# Altium schematic sheet CH1_4_INPUT_CONDITIONING.SchDoc(CH1_4_INPUT_CONDITIONING)
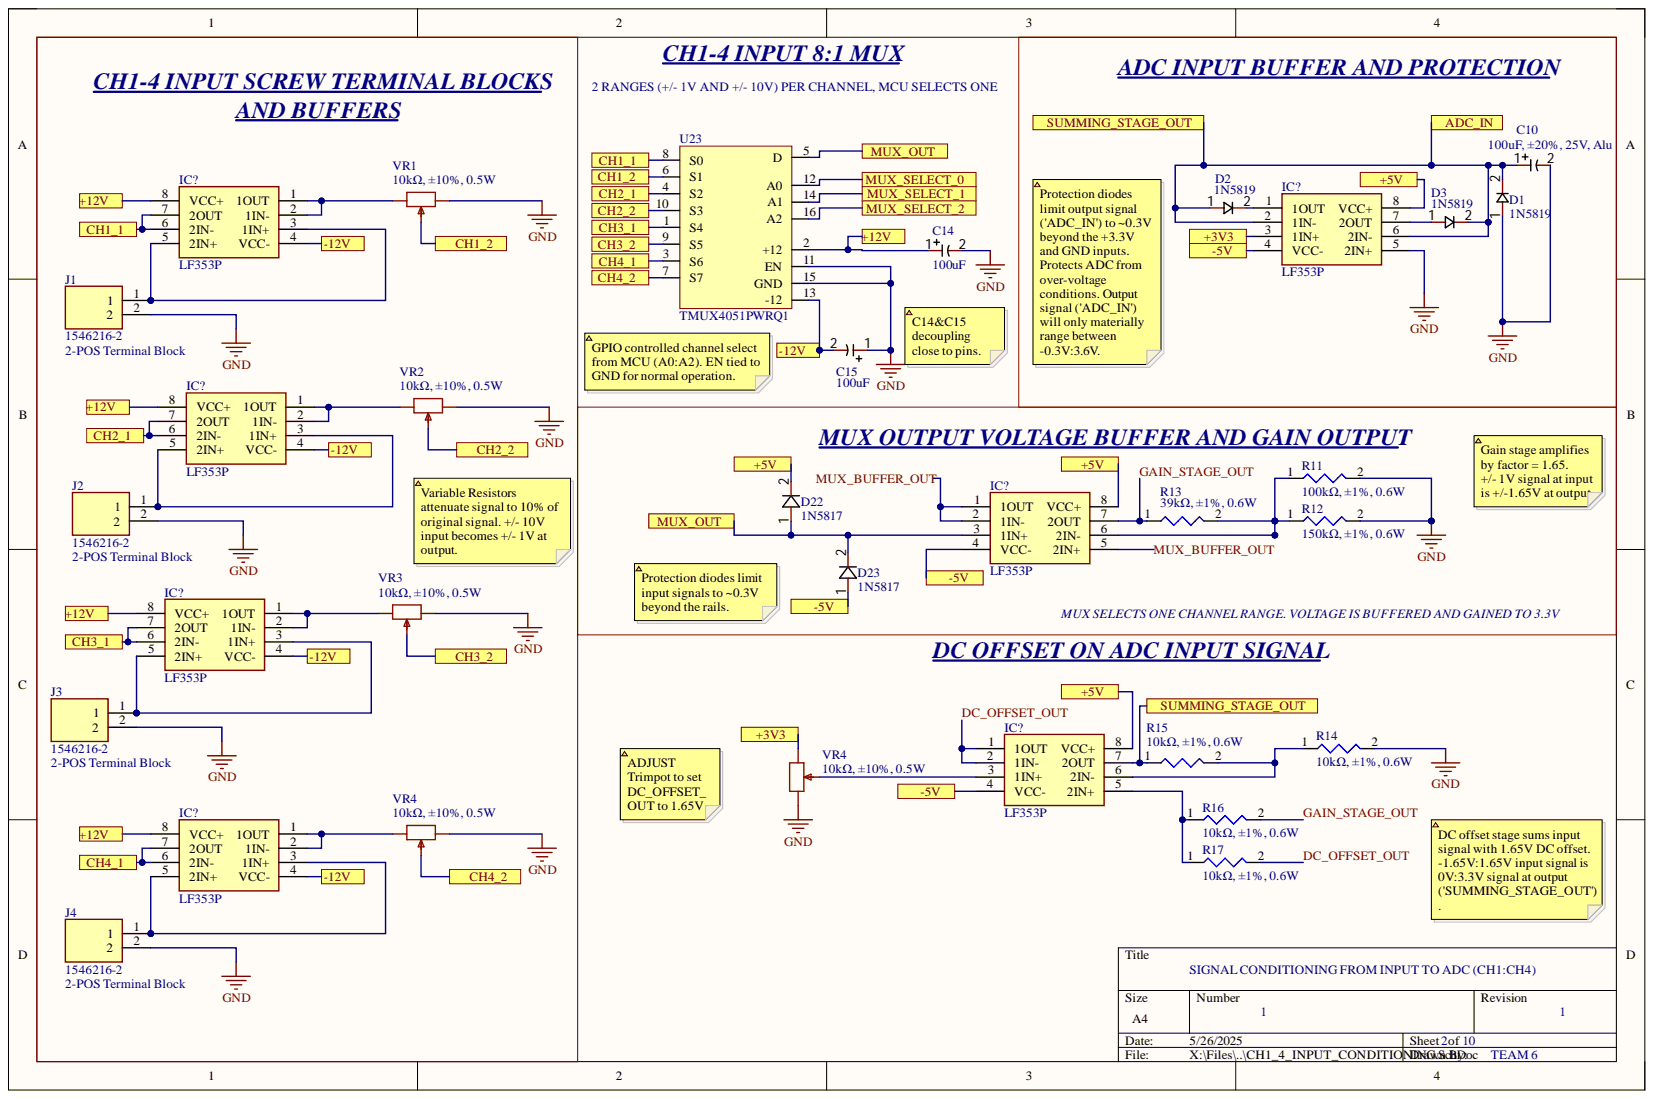
[diagram p0: CH1_4_INPUT_CONDITIONING.SchDoc]

## Parts
C10  100uF, ±20%, 25V, Alu  [100uF]
C14  100uF  [100uF]
C15  100uF  [100uF]
D1  1N5819  [1N5819]
D2  1N5819  [1N5819]
D3  1N5819  [1N5819]
D22  1N5817  [1N5817]
D23  1N5817  [1N5817]
IC?  LF353P  [DIP794W53P254L959H508Q8N]
IC?  LF353P  [DIP794W53P254L959H508Q8N]
IC?  LF353P  [DIP794W53P254L959H508Q8N]
IC?  LF353P  [DIP794W53P254L959H508Q8N]
IC?  LF353P  [DIP794W53P254L959H508Q8N]
IC?  LF353P  [DIP794W53P254L959H508Q8N]
IC?  LF353P  [DIP794W53P254L959H508Q8N]
J1  2-POS Terminal Block  [SHDR2W100P0X500_1X2_1000X820X1000P]
J2  2-POS Terminal Block  [SHDR2W100P0X500_1X2_1000X820X1000P]
J3  2-POS Terminal Block  [SHDR2W100P0X500_1X2_1000X820X1000P]
J4  2-POS Terminal Block  [SHDR2W100P0X500_1X2_1000X820X1000P]
R11  100kΩ, ±1%, 0.6W  [Resistor]
R12  150kΩ, ±1%, 0.6W  [Resistor]
R13  39kΩ, ±1%, 0.6W  [Resistor]
R14  10kΩ, ±1%, 0.6W  [Resistor]
R15  10kΩ, ±1%, 0.6W  [Resistor]
R16  10kΩ, ±1%, 0.6W  [Resistor]
R17  10kΩ, ±1%, 0.6W  [Resistor]
U23  TMUX4051PWRQ1  [TMUX4051PWRQ1]
VR1  10kΩ, ±10%, 0.5W  [Trimpot]
VR2  10kΩ, ±10%, 0.5W  [Trimpot]
VR3  10kΩ, ±10%, 0.5W  [Trimpot]
VR4  10kΩ, ±10%, 0.5W  [Trimpot]
VR4  10kΩ, ±10%, 0.5W  [Trimpot]

## Ports
+3V3, +5V, +12V, -5V, -12V, ADC_IN, CH1_1, CH1_2, CH2_1, CH2_2, CH3_1, CH3_2, CH4_1, CH4_2, MUX_OUT, MUX_SELECT_0, MUX_SELECT_1, MUX_SELECT_2, SUMMING_STAGE_OUT

## Nets
GND: C10.2 C14.2 C15.1 D1.1 IC?.5 J1.2 J2.2 J3.2 J4.2 R11.2 R12.2 R14.2 U23.11(EN) U23.15(GND) VR1.1 VR2.1 VR3.1 VR4.1 VR4.3
+3V3: IC?.3 IC?.5 J1.1 J2.1 J3.1 J4.1 VR4.1 VR4.2 ; port +3V3
ADC_IN: C10.1 D1.2 D2.1 D3.2 IC?.2 IC?.6 IC?.7 R15.1 ; port ADC_IN, port SUMMING_STAGE_OUT
CH1_1: IC?.6 IC?.7 R11.1 R12.1 R13.2 R14.1 R15.2 U23.1(S4) U23.3(S6) U23.4(S2) U23.8(S0) ; port CH1_1, port CH2_1, port CH3_1, port CH4_1
CH1_2: U23.6(S1) VR1.2 ; port CH1_2
CH2_2: U23.10(S3) VR2.2 ; port CH2_2
CH3_2: U23.9(S5) VR3.2 ; port CH3_2
CH4_2: U23.7(S7) VR4.2 ; port CH4_2
DC_OFFSET_OUT: IC?.1 IC?.2 R17.2
GAIN_STAGE_OUT: IC?.7 R13.1 R16.2
MUX_BUFFER_OUT: IC?.1 IC?.2 IC?.5
MUX_OUT: D22.1 D23.2 IC?.3 U23.5(D) ; port MUX_OUT
MUX_SELECT_0: U23.12(A0) ; port MUX_SELECT_0
MUX_SELECT_1: U23.14(A1) ; port MUX_SELECT_1
MUX_SELECT_2: U23.16(A2) ; port MUX_SELECT_2
NetC14_1: C14.1 IC?.8 U23.2(+12)
NetC15_2: C15.2 IC?.4 U23.13(-12)
NetD2_2: D2.2 IC?.1
NetD3_1: D3.1 IC?.7
NetD22_2: D22.2 IC?.8
NetD23_1: D23.1 IC?.4
NetIC?_1: IC?.1 IC?.2 VR1.3 VR2.3 VR3.3 VR4.3
NetIC?_5: IC?.5 R16.1 R17.1
CH4_1:

## Library data of the parts
C10 = 100uF: Component Kind: Standard; Library Name: 3800.SchLib
IC? IC? IC? IC? IC? IC? IC? = LF353P: Integrated Circuit; Manufacturer_Name: Texas Instruments; Arrow Part Number: LF353P; Arrow Price/Stock: https://www.arrow.com/en/products/lf353p/texas-instruments?utm_currency=USD&region=europe; Component Kind: Standard; Datasheet Link: http://www.ti.com/lit/ds/symlink/lf353.pdf; Height: 5.08mm; Manufacturer_Part_Number: LF353P; Mouser Part Number: 595-LF353P; Mouser Price/Stock: https://www.mouser.co.uk/ProductDetail/Texas-Instruments/LF353P?qs=EIjG%252BN7kn%252BnHJN43AgArjw%3D%3D
J1-J4 = 1546216-2: Connector; Manufacturer_Name: TE Connectivity; Arrow Part Number: 1546216-2; Arrow Price/Stock: https://www.arrow.com/en/products/1546216-2/te-connectivity?region=nac; Component Kind: Standard; Datasheet Link: https://www.te.com/commerce/DocumentDelivery/DDEController?Action=srchrtrv&DocNm=1-1773458-1_EURO_STYLE_QRG&DocType=Data%20Sheet&DocLang=English&PartCntxt=1546216-2&DocFormat=pdf; Height: 10mm; Manufacturer_Part_Number: 1546216-2; Mouser Part Number: 571-1546216-2; Mouser Price/Stock: https://www.mouser.co.uk/ProductDetail/TE-Connectivity/1546216-2?qs=Lxq28tKK6BMEq1xb2m0gjg%3D%3D
R11 R16 R17 = CF14JT10K0: Res Carbon Film 10K Ohm 5% 0.25W(1/4W) -500ppm/||; Manufacturer: Stackpole Electronics; Category: Through-Hole Resistors; Component Kind: Standard; ComponentLink1Description: http://components.arrow.com/part/dataview/27619991S9848636N1174?src-supplier=Arrow+Electronics; ComponentLink1URL: http://components.arrow.com/part/dataview/27619991S9848636N1174?src-supplier=Arrow+Electronics; ComponentLink2Description: https://www.seielect.com/catalog/SEI-Packaging.pdf?src-supplier=Digikey; ComponentLink2URL: https://www.seielect.com/catalog/SEI-Packaging.pdf?src-supplier=Digikey; ComponentLink3Description: https://www.verical.com/server-webapp/html/getDatasheet?partID=367360&src-supplier=Verical; ComponentLink3URL: https://www.verical.com/server-webapp/html/getDatasheet?partID=367360&src-supplier=Verical; ComponentLink4Description: https://www.seielect.com/catalog/SEI-CF_CFM.pdf?src-supplier=Avnet; ComponentLink4URL: https://www.seielect.com/catalog/SEI-CF_CFM.pdf?src-supplier=Avnet; ComponentLink5Description: http://www.seielect.com/Catalog/SEI-CF_CFM.pdf?src-supplier=Future+Electronics; ComponentLink5URL: http://www.seielect.com/Catalog/SEI-CF_CFM.pdf?src-supplier=Future+Electronics; ComponentLink6Description: https://datasheet.octopart.com/CF14JT10K0-Stackpole-Electronics-datasheet-8325406.pdf?src-supplier=Future+Electronics; ComponentLink6URL: https://datasheet.octopart.com/CF14JT10K0-Stackpole-Electronics-datasheet-8325406.pdf?src-supplier=Future+Electronics; Library Name: Copy of Schlib2.SchLib; Manufacturer Part Number: CF14JT10K0; Pricing 1: 1=0.1, 10=0.053, 25=0.0404, 50=0.0308, 100=0.0215, 250=0.01728, 500=0.01382, 1000=0.01017 (USD); RoHS: Yes; Stock 1: 434821; Supplier 1: Digikey; Supplier Part Number 1: CF14JT10K0CT-ND
R12-R15 = MRS25000C1002FRP00: Fixed Resistor, Thin Film, 0.6W, 10000ohm, 350V, 1% +/-Tol, 50ppm/Cel, Through Hole Mount; Manufacturer: Vishay BCcomponents; Category: Through-Hole Resistors; Component Kind: Standard; ComponentLink1Description: https://datasheet.ciiva.com/3258/mrs16m25-3258505.pdf?src-supplier=Avnet; ComponentLink1URL: https://datasheet.ciiva.com/3258/mrs16m25-3258505.pdf?src-supplier=Avnet; ComponentLink2Description: https://datasheet.ciiva.com/2710/mrs16m25-2710776.pdf?src-supplier=Digikey; ComponentLink2URL: https://datasheet.ciiva.com/2710/mrs16m25-2710776.pdf?src-supplier=Digikey; ComponentLink3Description: https://datasheet.ciiva.com/2710/mrs16m25-2710777.pdf?src-supplier=Digikey; ComponentLink3URL: https://datasheet.ciiva.com/2710/mrs16m25-2710777.pdf?src-supplier=Digikey; ComponentLink4Description: https://datasheet.ciiva.com/8399/mrs16m25-8399786.pdf?src-supplier=Avnet; ComponentLink4URL: https://datasheet.ciiva.com/8399/mrs16m25-8399786.pdf?src-supplier=Avnet; ComponentLink5Description: https://datasheet.ciiva.com/48465/mrs16m25-48465878.pdf?src-supplier=Avnet; ComponentLink5URL: https://datasheet.ciiva.com/48465/mrs16m25-48465878.pdf?src-supplier=Avnet; ComponentLink6Description: https://datasheet.ciiva.com/7836/mrs16m25-7836236.pdf?src-supplier=Element14; ComponentLink6URL: https://datasheet.ciiva.com/7836/mrs16m25-7836236.pdf?src-supplier=Element14; Library Name: Copy of Schlib2.SchLib; Manufacturer Part Number: MRS25000C1002FRP00; Pricing 1: 1=0.29, 10=0.237, 25=0.1812, 50=0.1314, 100=0.0925, 250=0.07476, 500=0.05892, 1000=0.04079 (USD); RoHS: Yes; Stock 1: 11724; Supplier 1: Digikey; Supplier Part Number 1: PPC10.0KZCT-ND
VR1-VR4 = Trimpot: Component Kind: Standard; Library Name: 3800.SchLib
VR4 = Trimpot: 10kOhm 0.125W; Component Kind: Standard; Library Name: 3800.SchLib
C14 C15 = 100uF: Component Kind: Standard; Library Name: 3800.SchLib
D1-D3 = 1N5819: Component Kind: Standard; Library Name: 3800.SchLib
D22 D23 = 1N5817: Component Kind: Standard; Library Name: Product-PCB.SchLib
U23 = TMUX4051PWRQ1: Component Kind: Standard; Library Name: 3800.SchLib
